# Altium schematic sheet POWER_RAIL.SchDoc(POWER_RAIL)
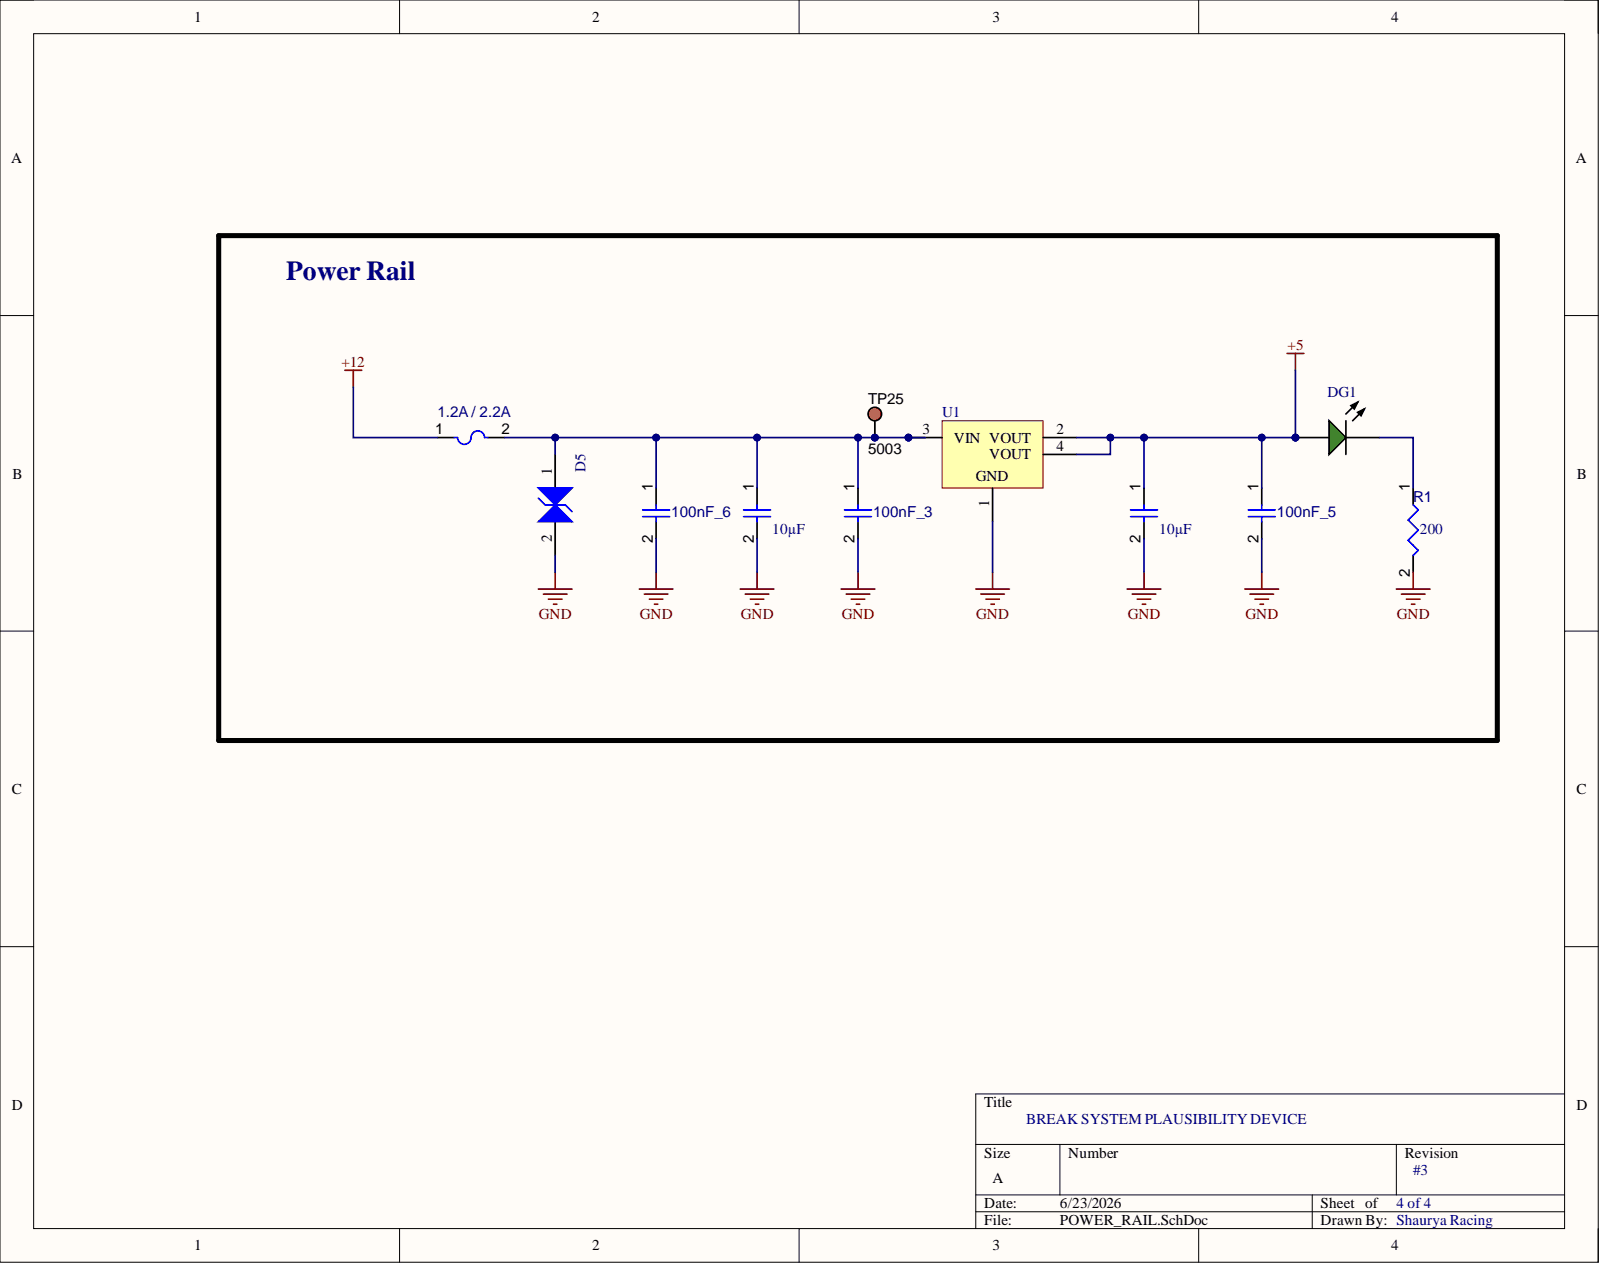
[diagram p0: POWER_RAIL.SchDoc]

## Parts
1.2A_/_2.2A  MF-MSMF110/24X  (value not drawn)
100nF_3  Capacitor 100nF +/-20% 25V 0402  (value not drawn)
100nF_5  Capacitor 100nF +/-20% 25V 0402  (value not drawn)
100nF_6  Capacitor 100nF +/-20% 25V 0402  (value not drawn)
C  value 10µF  (hidden comment: Capacitor 10µF +/-40% 6.3V 0402)
C_2  value 10µF  (hidden comment: Capacitor 10µF +/-40% 6.3V 0402)
D5  824551121  (value not drawn)
DG1  WP934CB/GD  (value not drawn)
R1  value 200  (hidden comment: 200R2J)
TP25  5003
U1  LM1117IMP-5.0-NOPB  (value not drawn)

## Nets
+5: 100nF_5.1 C.1 DG1.2 U1.2(VOUT) U1.4(VOUT)
+12: 1.2A_/_2.2A.1
GND: 100nF_3.2 100nF_5.2 100nF_6.2 C.2 C_2.2 D5.2 R1.2 U1.1
Net1.2A / 2.2A_2: 1.2A_/_2.2A.2 100nF_3.1 100nF_6.1 C_2.1 D5.1 TP25.1 U1.3(VIN)
NetDG1_1: DG1.1 R1.1

## Library data of the parts
1.2A_/_2.2A = CMP-005-00008-3: PTC Resettable Fuse, 24 V, 20 A, -40 to 85 degC, 2-Pin SMD, RoHS, Tape and Reel; Manufacturer: Bourns; Current Rating: 20A; Case/Package: MF-MSMF110-24X-2; Component Kind: Standard; Datasheet URL: http://www.bourns.com/docs/Product-Datasheets/mfmsmf.pdf; Datasheet Version: Rev. AG, 08/2016; Mounting Technology: SM; Package Description: 2-Pin Surface Mount Device, Body 4.6 x 3.24 mm; Package Reference: MF-MSMF110-24X-2; RoHS Compliant: False; Library Name: APAC - VIT, Chennai - Shaurya Racing
100nF_3 100nF_5 100nF_6 = CMP-001-00065-4: Chip Capacitor, 100nF +/-20%, 25V, 0402, Thickness 0.6 mm; Tolerance: 10%; Case/Package: 0402; Component Kind: Standard; Max Operating Temperature: 85°C; Min Operating Temperature: -55°C; Mounting Technology: SMT; Pins: 2; Value: 100nF; Voltage Rating: 25V; Library Name: APAC - VIT, Chennai - Shaurya Racing
C C_2 = CMP-001-00074-3: Chip Capacitor, 10µF +/-40%, 6.3V, 0402, Thickness 0.6 mm; Tolerance: 10%; Case/Package: 0402; Component Kind: Standard; Max Operating Temperature: 85°C; Min Operating Temperature: -55°C; Mounting Technology: SMT; Pins: 2; Voltage Rating: 6.3V; Library Name: APAC - VIT, Chennai - Shaurya Racing
D5 = CMP-03913-71101758-2: TVS diode [Würth Elektronik] 824551121 TVS diode; Breakdown Voltage: 14V; Clamping Voltage: 19.9V; Leakage Current: 2µA; Number of Bidirectional Channels: 1; Peak Pulse Current: 150.8A; Peak Pulse Power: 3kW; Polarity: Bidirectional; Power Line Protection: No; Reverse Standoff Voltage: 12V; Component Kind: Standard; Composition: Zener; Height: 2.5mm; Length: 6.86mm; Library Name: Altium Content Vault; Max Breakdown Voltage: 14V; Max Operating Temperature: 150°C; Min Breakdown Voltage: 14V; Min Operating Temperature: -65°C; Mount: Surface Mount; Number of Pins: 2; Packaging: Cut Tape; REACH SVHC: Unknown; RoHS: Compliant; Width: 5.91mm
DG1 = CMP-2000-07417-1: Right Angle LED Indicator, 62.5 mW, 25 mA, -40 to 85 degC, 2-Pin THD, RoHS, Bulk; Manufacturer: Kingbright; 3D Model: WP934CB/x.sldprt  WP934CB/x.pro  WP934CB/x.igs  WP934CB/x.stp; Catalog Drawings: WP934CBSeriesFront  WP934CBSeriesSide; Configuration: Single; Current: 20mA; Dynamic Catalog: SingleLevel3mm(T1)SingleColorRightAngleLEDs; Lens Style/Size: RoundwithDomedTop,3mm; Lens Type: Diffused,Tinted; Millicandela Rating: 22.5mcd; Minimum Quantity: Green; Other Names: 754-1297 WP934CBGD; Viewing Angle: 40°; Voltage Rating: 2.2V; Wavelength - Peak: 568nm; Category: Optoelectronics; Color: Green; Component Kind: Standard; Datasheet URL: http://www.kingbrightusa.com/images/catalog/SPEC/WP934CB-GD.pdf; Datasheet Version: Rev. 10B, 09/2016; Family: LEDs-CircuitBoardIndicators,Arrays,LightBars,BarGraphs; Library Name: Altium Content Vault; Manufacturer URL: http://www.kingbright.com/; Mounting Technology: ThroughHole; Mounting Type: ThroughHole,RightAngle; Package Description: 2-Pin Through Hole Device, Body 4.6 x 8.75 mm; Package Reference: WP934CBGD; Packaging: Bulk; Quantity Available: Bulk; Standard Package: 500
R1 = CMP-009-00079-2: 200R 0.063W 5% 0402 (1005 Metric)  SMD; Power: 63mW; Case/Package: 0402; Component Kind: Standard; Mounting Technology: SMT; Pins: 2; Tolerance: 5%; Library Name: APAC - VIT, Chennai - Shaurya Racing
TP25 = CMP-002-00037-2: Test Point, Orange, Height 4.6 mm, Tail Length 3 mm, 1-Pin THD, RoHS; Manufacturer: Keystone; Case/Package: 5003; Colour: Orange; Component Kind: Standard; Connector Type: TestPoint; Contact Material: Bronze; Contact Plating: Tin; Datasheet URL: http://keyelco.com/userAssets/file/M65p56.pdf; Datasheet Version: Jun-15; Diameter: 2.54mm; Manufacturer URL: http://www.keyelco.com/; Mounting Technology: TH; Package Description: 1-Pin Through Hole Device, Dia 2.54 mm; Package Quantity: 10; Package Reference: 5003; Packaging: Bulk; Pins: 1; RoHS Compliant: True; Library Name: APAC - VIT, Chennai - Shaurya Racing
U1 = CMP-019-00021-3: Low-Dropout Linear Regulator, 800 mA, -40 to 125 degC, 4-Pin SOT-223 (DCY), Green (RoHS & no Sb/Br), Tape and Reel; Manufacturer: Texas Instruments; Dropout Voltage: 1.2V; Max Input Voltage: 15V; Max Ouput Current: 800mA; Min Input Voltage: 2.6V; Nominal Output Voltage: 5V; Outputs: 1; Case/Package: SOT-223; Component Kind: Standard; Datasheet URL: http://www.ti.com/lit/ds/symlink/lm1117.pdf; Datasheet Version: SNOS412N, 01/2016; Manufacturer URL: http://www.ti.com/; Max Operating Temperature: 125°C; Min Operating Temperature: -40°C; Mounting Technology: SM; Output Current: 800mA; Output Voltage: 5V; Package Description: 4-Pin Small Outline Transistor, Body 6.5 x 3.5 mm, Pitch 2.3 mm; Package Reference: DCY0004A; Packaging: TapeandReel; Pins: 4; RoHS Compliant: True; Library Name: APAC - VIT, Chennai - Shaurya Racing
